# Altium schematic sheet Sheet1.SchDoc
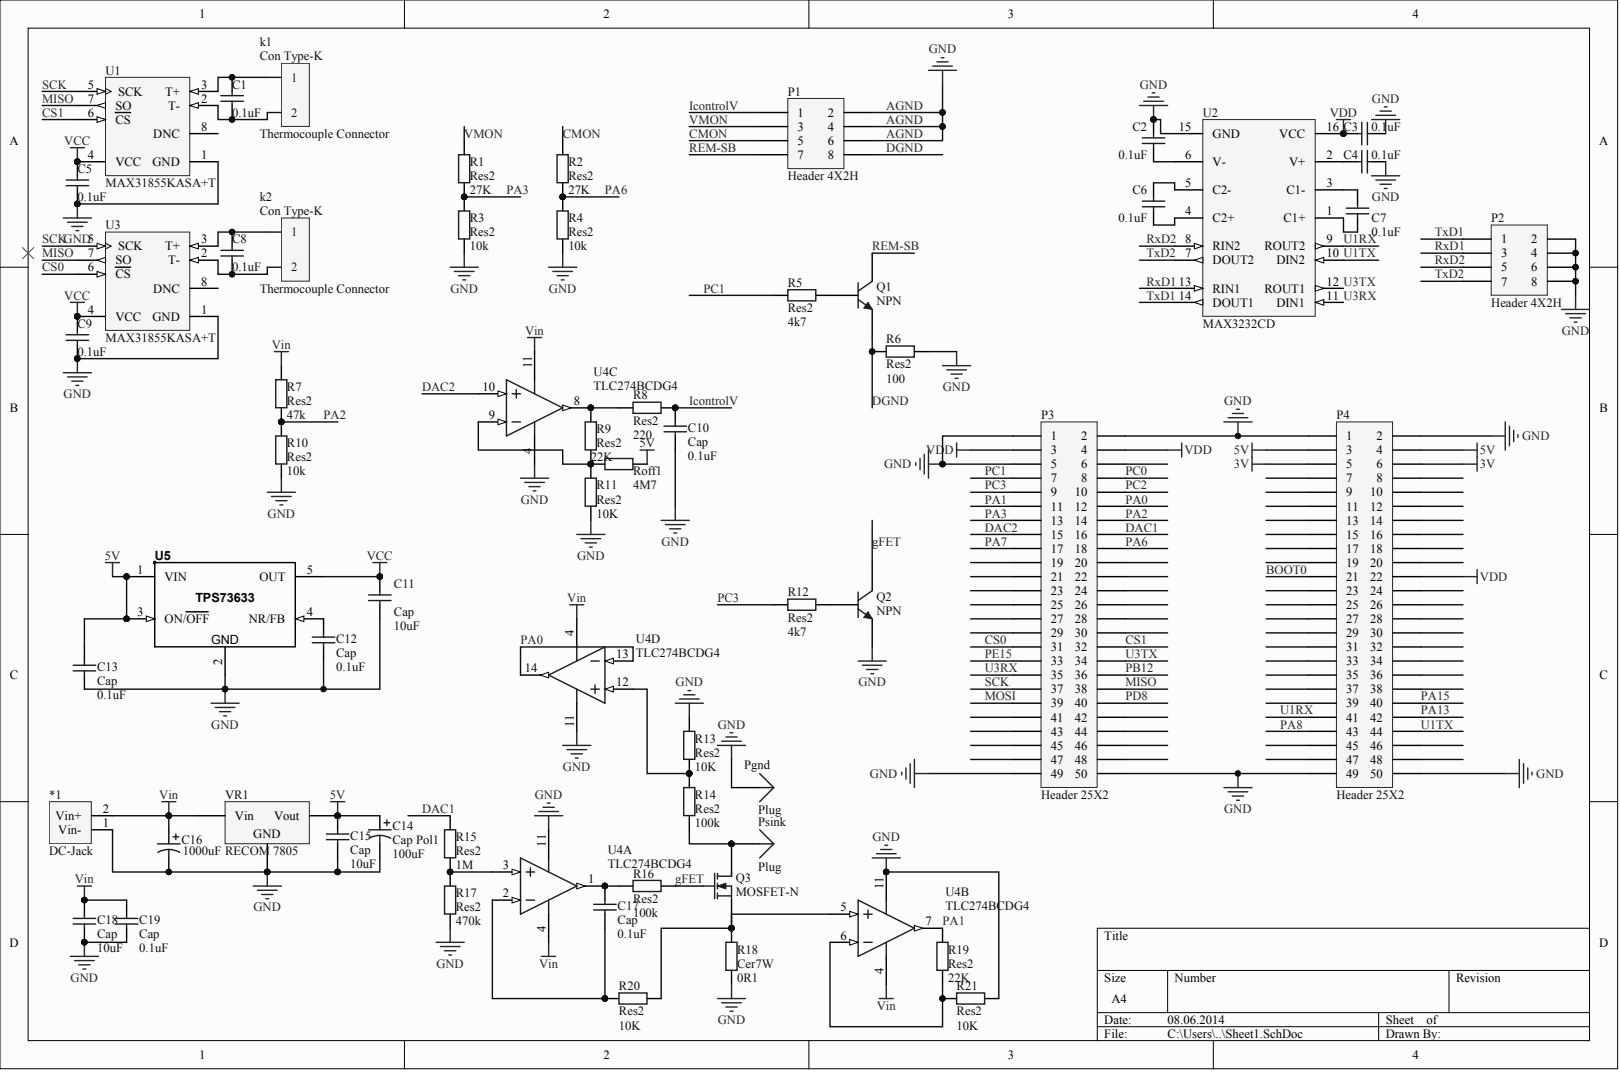
[diagram p0: Sheet1.SchDoc]

## Parts
*1  DC-Jack
C1  value 0.1uF  (hidden comment: Cap)
C2  value 0.1uF  (hidden comment: Cap)
C3  value 0.1uF  (hidden comment: Cap)
C4  value 0.1uF  (hidden comment: Cap)
C5  value 0.1uF  (hidden comment: Cap)
C6  value 0.1uF  (hidden comment: Cap)
C7  value 0.1uF  (hidden comment: Cap)
C8  value 0.1uF  (hidden comment: Cap)
C9  value 0.1uF  (hidden comment: Cap)
C10  Cap  value 0.1uF
C11  Cap  value 10uF
C12  Cap  value 0.1uF
C13  Cap  value 0.1uF
C14  Cap Pol1  value 100uF
C15  Cap  value 10uF
C16  value 1000uF  (hidden comment: Cap Pol1)
C17  Cap  value 0.1uF
C18  Cap  value 10uF
C19  Cap  value 0.1uF
k1  Thermocouple Connector
k2  Thermocouple Connector
P1  Header 4X2H
P2  Header 4X2H
P3  Header 25X2
P4  Header 25X2
Pgnd  Plug
Psink  Plug
Q1  NPN
Q2  NPN
Q3  MOSFET-N
R1  Res2  value 27K
R2  Res2  value 27K
R3  Res2  value 10k
R4  Res2  value 10k
R5  Res2  value 4k7
R6  Res2  value 100
R7  Res2  value 47k
R8  Res2  value 220
R9  Res2  value 22K
R10  Res2  value 10k
R11  Res2  value 10K
R12  Res2  value 4k7
R13  Res2  value 10K
R14  Res2  value 100k
R15  Res2  value 1M
R16  Res2  value 100k
R17  Res2  value 470k
R18  Cer7W  value 0R1
R19  Res2  value 22K
R20  Res2  value 10K
R21  Res2  value 10K
Roff1  Res2  value 4M7
U1  MAX31855KASA+T
U2  MAX3232CD
U3  MAX31855KASA+T
U4  TLC274BCDG4  (parts A,B,C,D)
U5  3.3V  (value not drawn)
VR1  RECOM 7805

## Nets
3V: P4.5 P4.6
5V: C13.2 C14.1 C15.2 P4.3 P4.4 Roff1.1 U5.1(VIN) U5.3(ON/OFF) VR1.3
VCC: C5.2 C9.2 C11.2 U1.4(VCC) U3.4(VCC) U5.5(OUT)
VDD: C3.2 P3.3 P3.4 P4.22 U2.16(VCC)
VIN: *1.2 C16.1 C18.2 C19.2 R7.2 U4.4 U4.11 VR1.1
AGND: *1.1 C2.2 C3.1 C4.1 C5.1 C9.1 C10.1 C11.1 C12.1 C13.1 C14.2 C15.1 C16.2 C18.1 C19.1 P1.2 P1.4 P1.6 P2.2 P2.4 P2.6 P2.8 P3.1 P3.2 P3.5 P3.49 P3.50 P4.1 P4.2 P4.49 P4.50 Pgnd.1 Q2.3 R3.1 R4.1 R6.1 R10.1 R11.1 R13.2 R17.1 R18.1 R21.1 U1.1(GND) U2.15(GND) U3.1(GND) U4.4 U4.11 U5.2 VR1.2
BOOT0: P4.21
CMON: P1.5 R2.2
CS0: P3.31 U3.6(CS)
CS1: P3.32 U1.6(CS)
DAC1: P3.16 R15.2
DAC2: P3.15 U4.10
DGND: P1.8 Q1.3 R6.2
GFET: Q2.1 Q3.2 R16.2
ICONTROLV: C10.2 P1.1 R8.2
MISO: P3.38 U1.7(SO) U3.7(SO)
MOSI: P3.39
PA0: P3.12 U4.13 U4.14
PA1: P3.11 R19.2 U4.7
PA2: P3.14 R7.1 R10.2
PA3: P3.13 R1.1 R3.2
PA6: P3.18 R2.1 R4.2
PA7: P3.17
PA8: P4.43
PA13: P4.42
PA15: P4.40
PB12: P3.36
PC0: P3.8
PC1: P3.7 R5.2
PC2: P3.10
PC3: P3.9 R12.2
PD8: P3.40
PE15: P3.33
REM-SB: P1.7 Q1.1
RXD1: P2.3 U2.13(RIN1)
RXD2: P2.5 U2.8(RIN2)
SCK: P3.37 U1.5(SCK) U3.5(SCK)
TXD1: P2.1 U2.14(DOUT1)
TXD2: P2.7 U2.7(DOUT2)
U1RX: P4.41 U2.9(ROUT2)
U1TX: P4.44 U2.10(DIN2)
U3RX: P3.35 U2.11(DIN1)
U3TX: P3.34 U2.12(ROUT1)
VMON: P1.3 R1.2
NetC1_1: C1.1 k1.2 U1.2(T-)
NetC1_2: C1.2 k1.1 U1.3(T+)
NetC2_1: C2.1 U2.6(V-)
NetC4_2: C4.2 U2.2(V+)
NetC6_1: C6.1 U2.4(C2+)
NetC6_2: C6.2 U2.5(C2-)
NetC7_1: C7.1 U2.1(C1+)
NetC7_2: C7.2 U2.3(C1-)
NetC8_1: C8.1 k2.2 U3.2(T-)
NetC8_2: C8.2 k2.1 U3.3(T+)
NetC12_2: C12.2 U5.4(NR/FB)
NetC17_1: C17.1 R20.1 U4.2
NetC17_2: C17.2 R16.1 U4.1
NetPsink_1: Psink.1 Q3.1 R14.1
NetQ1_2: Q1.2 R5.1
NetQ2_2: Q2.2 R12.1
NetQ3_3: Q3.3 R18.2 R20.2 U4.5
NetR8_1: R8.1 R9.2 U4.8
NetR9_1: R9.1 R11.2 Roff1.2 U4.9
NetR13_1: R13.1 R14.2 U4.12
NetR15_1: R15.1 R17.2 U4.3
NetR19_1: R19.1 R21.2 U4.6

Not connected: P3 6 19 20 21 22 23 24 25 26 27 28 29 30 41 42 43 44 45 46 47 48; P4 7 8 9 10 11 12 13 14 15 16 17 18 19 20 23 24 25 26 27 28 29 30 31 32 33 34 35 36 37 38 39 45 46 47 48; U1 8(DNC); U3 8(DNC)

## Library data of the parts
R8 = (no symbol name): Value: 220; Published: 8-Jun-2000; LatestRevisionDate: 17-Jul-2002; LatestRevisionNote: Re-released for DXP Platform.; PackageReference: AXIAL-0.4; PackageDescription: Axial Device, Thru-Hole; 2 Leads; 0.4 in Pin Spacing; Publisher: Altium Limited
R16 = (no symbol name): Value: 100k; Published: 8-Jun-2000; LatestRevisionDate: 17-Jul-2002; LatestRevisionNote: Re-released for DXP Platform.; PackageReference: AXIAL-0.4; PackageDescription: Axial Device, Thru-Hole; 2 Leads; 0.4 in Pin Spacing; Publisher: Altium Limited
U1 U3 = (no symbol name): Functions: Thermocouple-to-Digital Converter; Interface: SPI; Packing: Tape ang Reel; Parasite Pwr.: No; DatasheetVersion: Rev. 2; PackageVersion: Rev. D, 10/2012; PackageReference: S8+4; PackageDescription: 8-Pin Small-Outline IC, Narrow, Body 3.9 x 4.9 mm, Pitch 1.27 mm; Supplier 1: Digi-Key; Supplier Part Number 1: MAX31855KASA+TTR-ND; Supplier 2: Digi-Key; Supplier Part Number 2: MAX31855KASA+TCT-ND; Supplier 3: Digi-Key; Supplier Part Number 3: MAX31855KASA+TDKR-ND; Supplier 4: Mouser; Supplier Part Number 4: 700-MAX31855KASA+T; Generic Part Number: MAX31855; Mounting Technology: Surface Mount; Code_JEDEC: MS-012; PartNumber: MAX31855KASA+T; ComponentLink1Description: Manufacturer URL; ComponentLink1URL: http://www.maximintegrated.com/; ComponentLink2Description: Datasheet; ComponentLink2URL: http://datasheets.maximintegrated.com/en/ds/MAX31855.pdf; ComponentLink3Description: Package Specification; ComponentLink3URL: http://pdfserv.maximintegrated.com/package_dwgs/21-0041.PDF
U2 = (no symbol name): SubFamily: RS-232; Drivers Per Package: 2; Supply Voltage(s)(V): 3.3 or 5.0; ESD HBM(kV): +/-15; ICC(Max)(mA): 1; Single Supply: TRUE; DatasheetVersion: SLLS410I; PackageVersion: revM, Jun-2011; PackageReference: D16; PackageDescription: 16-Pin Plastic Small Outline Integrated Circuit, 9.9 x 3.9 mm, Pitch 1.27 mm Pitch; Receivers Per Package: 2; RS-232: TRUE; ComponentLink1Description: Manufacturer URL; ComponentLink1URL: www.ti.com; ComponentLink2Description: Datasheet; ComponentLink2URL: http://www.ti.com/general/docs/lit/getliterature.tsp?genericPartNumber=MAX3232&fileType=pdf; ComponentLink3Description: Package Specification; ComponentLink3URL: http://www.ti.com/litv/pdf/mpds178g
U4 = (no symbol name): Manufacturer: Texas Instruments; CMRR(Min)(dB): 65; Eco-Plan: Green (RoHS & no Sb/Br); GBW(Typ)(MHz): 1.7; IIB(Max)(pA): 60; Iq per channel(Max)(mA): 1.6; Number of Channels: 4; Offset Drift(Typ)(uV/C): 1.8; Rating: Catalog; Slew Rate(Typ)(V/us): 3.6; Sub Family: General Purpose Amplifier; Total Supply Voltage(Max)(+5V is 5, +/-5V is 10): 16; Total Supply Voltage(Min)(+5V is 5, +/-5V is 10): 3; Vio (25C)(Max)(mV): 2; Vn at 1kHz(Typ)(nV/rtHz): 25; DatasheetVersion: SLOS092D; PackageVersion: 4040047-5/M, 06/2011; PackageReference: D14; PackageDescription: 14-Pin Plastic Small Outline, Body 8.65 x 3.90 mm, Pitch 1.27 mm; Supplier 1: Digi-Key; Supplier Part Number 1: TLC274BCDG4-ND; Supplier 2: Farnell; Supplier Part Number 2: 1234898; Supplier 3: Newark; Supplier Part Number 3: 13M5529; Supplier 4: Newark; Supplier Part Number 4: 54K0952; Supplier 5: Mouser; Supplier Part Number 5: 595-TLC274BCDG4; Mounting Technology: Surface Mount; Code_JEDEC: MS-012; PartNumber: TLC274BCDG4; ComponentLink1Description: Manufacturer URL; ComponentLink1URL: http://www.ti.com/; ComponentLink2Description: Datasheet; ComponentLink2URL: http://www.ti.com/lit/ds/symlink/tlc274b.pdf; ComponentLink3Description: Package Specification; ComponentLink3URL: http://www.ti.com/lit/ml/mpds177g/mpds177g.pdf
U5 = (no symbol name): Manufacturer 1: Texas Instruments; Manufacturer Part Number 1: TPS73633DBVT; Voltage Regulator Type: Switching Buck; Tolerance: ±0.5%; Ratings: 5.5Vin Max; Value: 3.3V; 400mA; Regulator Topology: Positive Fixed; Voltage - Input: 3.5V to 5.5V; Voltage - Dropout (Typical): 0.075V @ 400mA; Current - Output: 400mA (Max); Current - Limit (Min): 400mA; SCDType: spec; Package / Case: SOT23-5; Supplier 1: Digi-Key; Supplier Part Number 1: 296-15819-1-ND; Voltage - Output: 3.3V; ComponentLink1URL: http://www.ti.com/lit/ds/symlink/tps73601.pdf; ComponentLink1Description: http://www.ti.com/lit/ds/symlink/tps73601.pdf
*1 C1-C19 k1 k2 P1-P4 Pgnd Psink Q1-Q3 R1-R7 R9-R15 R17-R21 Roff1 VR1 = (no symbol name)
  C1-C13 C15 C17-C19: Published: 8-Jun-2000; LatestRevisionDate: 17-Jul-2002; LatestRevisionNote: Re-released for DXP Platform.; PackageReference: RAD-0.3; PackageDescription: Radial Cap, Thru-Hole; 2 Leads; 0.3 in Pin Spacing
  C14 C16: Published: 8-Jun-2000; LatestRevisionDate: 17-Jul-2002; LatestRevisionNote: Re-released for DXP Platform.; PackageReference: RB7.6-15; PackageDescription: Polarized Capacitor (Radial); 2 Leads
  P1-P4 Pgnd Psink: LatestRevisionDate: 17-Jul-2002; LatestRevisionNote: Re-released for DXP Platform.
  Q1 Q2: Published: 8-Jun-2000; LatestRevisionDate: 17-Jul-2002; LatestRevisionNote: Re-released for DXP Platform.; PackageReference: TO-226-AA; PackageDescription: TO, Flat Index; 3 In-Line, Axial Leads; Body Dia. 4.8mm; Leads 0.55 x 0.51 mm (max); Code_JEDEC: TO-226-AA
  Q3: Published: 8-Jun-2000; LatestRevisionDate: 17-Jul-2002; LatestRevisionNote: Re-released for DXP Platform.; PackageVersion: 1995/1996; PackageReference: E3; PackageDescription: TO, Flat Index; 3 In-Line, Axial Leads; Body Dia. 4.6mm; Lead Dia. 0.5 mm (max)
  R1-R7 R9-R15 R17-R21 Roff1: Published: 8-Jun-2000; LatestRevisionDate: 17-Jul-2002; LatestRevisionNote: Re-released for DXP Platform.; PackageReference: AXIAL-0.4; PackageDescription: Axial Device, Thru-Hole; 2 Leads; 0.4 in Pin Spacing
  VR1: Published: 24-Mar-1999; LatestRevisionDate: 29-May-2009; LatestRevisionNote: IPC-7351 Footprint Added.; PackageReference: D2PAK; PackageDescription: 3-Pin Transistor Outline Package 2.54 mm Pitch, 15.1 mm Lead Span
  C1-C19 P1-P4 Pgnd Psink Q1-Q3 R1-R7 R9-R15 R17-R21 Roff1 VR1: Publisher: Altium Limited
